# Altium schematic sheet ExtInterface_26P.SchDoc
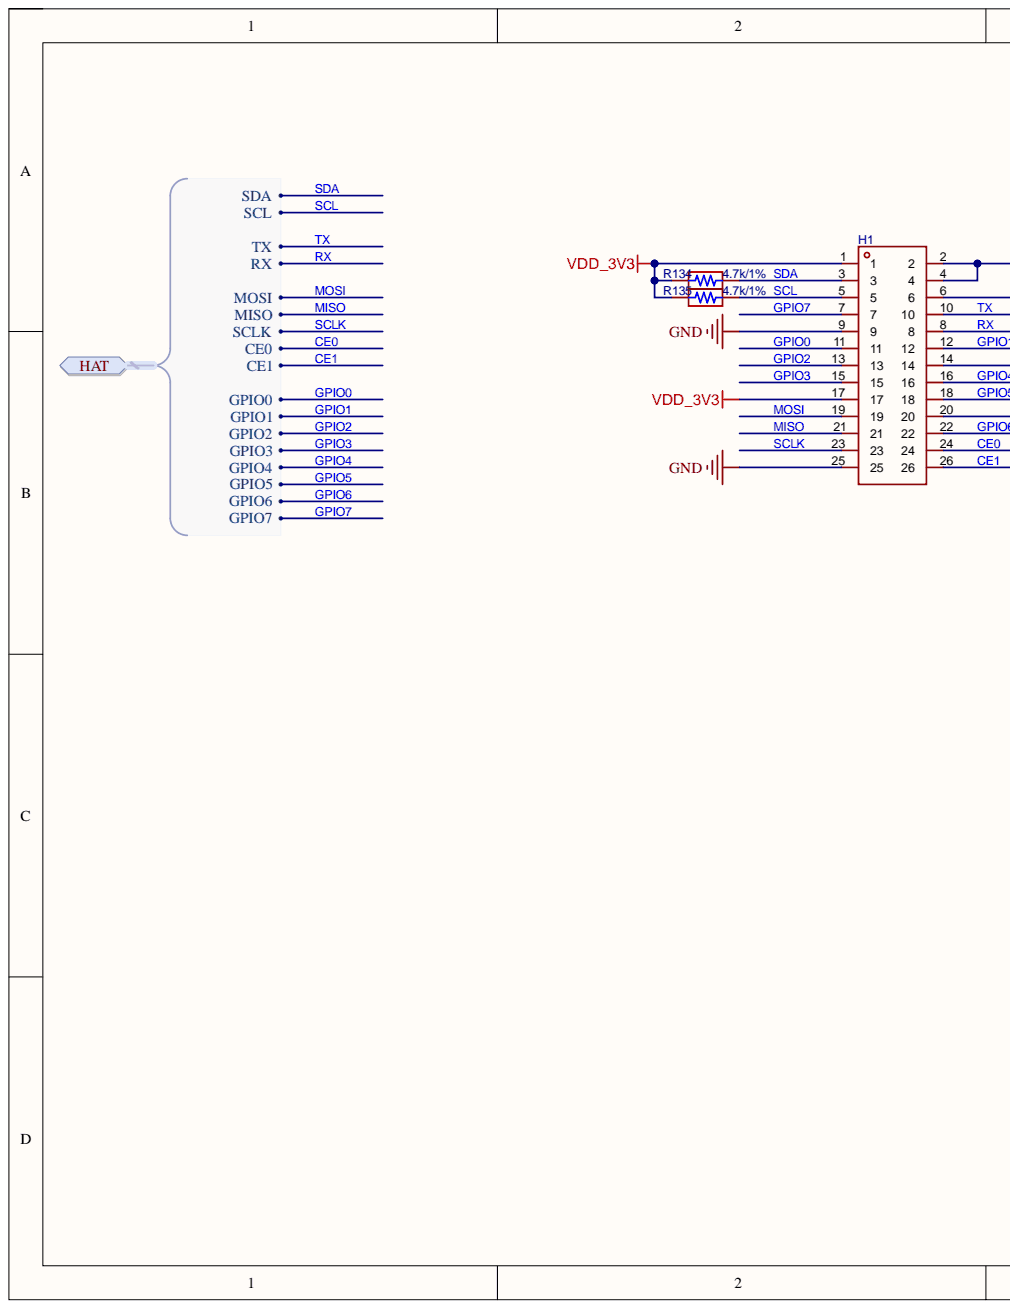
[diagram p0: ExtInterface_26P.SchDoc, left]
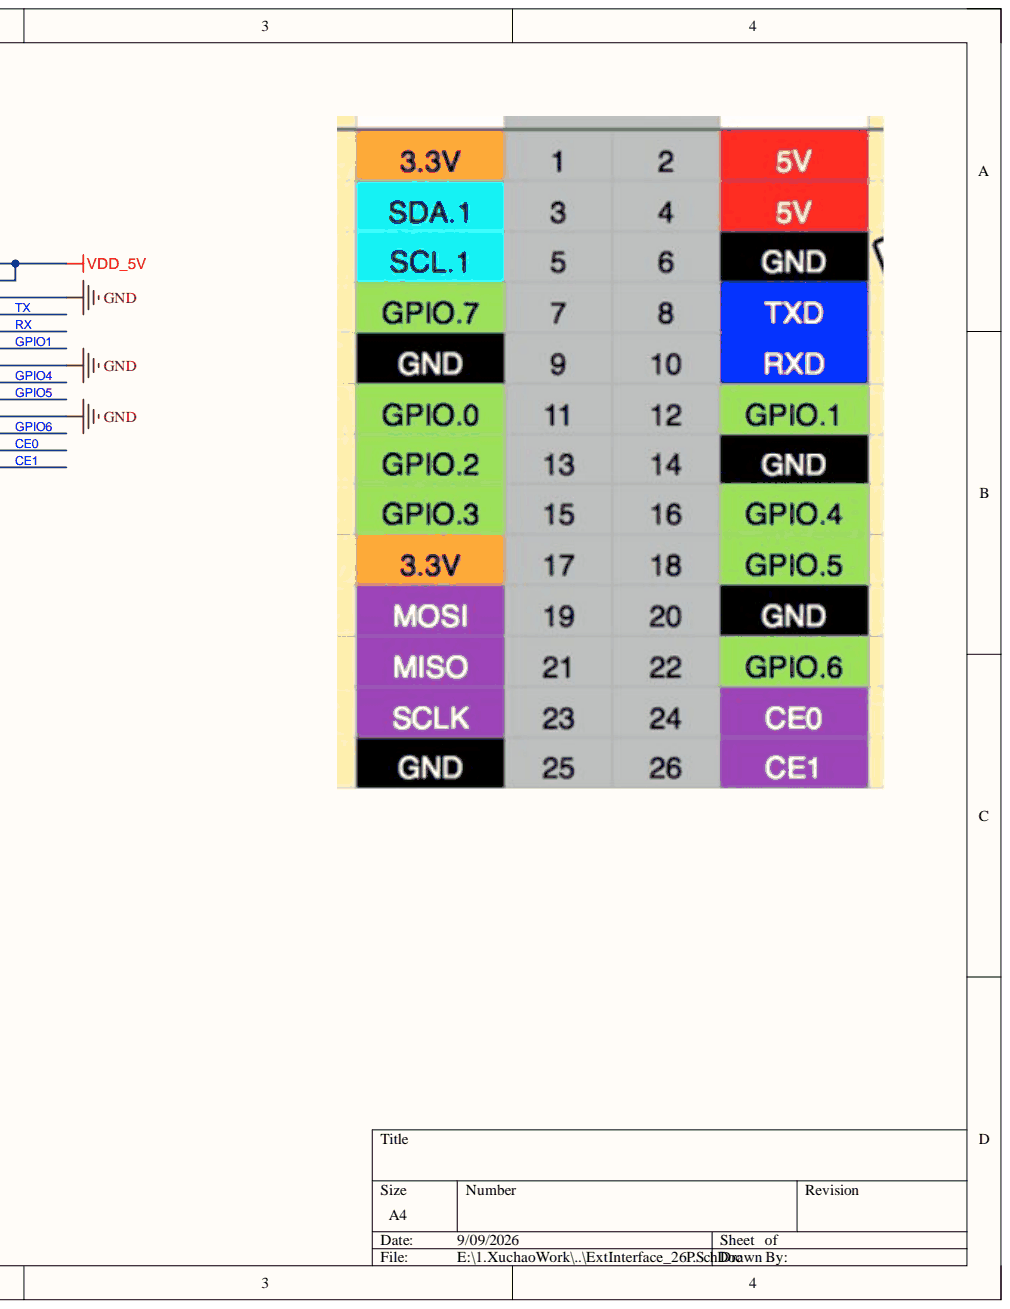
[diagram p1: ExtInterface_26P.SchDoc, right]

## Parts
H1  hc-pz254-11.5l-2x13pz  [HDR-TH_26P-P2.54-V-M-R2-C13-S2.54]  (value not drawn)
R134  4.7k/1%  [R0402]
R135  4.7k/1%  [R0402]

## Ports
HAT, HAT.CE0, HAT.CE1, HAT.GPIO0, HAT.GPIO1, HAT.GPIO2, HAT.GPIO3, HAT.GPIO4, HAT.GPIO5, HAT.GPIO6, HAT.GPIO7, HAT.MISO, HAT.MOSI, HAT.RX, HAT.SCL, HAT.SCLK, HAT.SDA, HAT.TX

## Nets
GND: H1.6 H1.9 H1.14 H1.20 H1.25
VDD_3V3: H1.1 H1.17 R134.2 R135.2
VDD_5V: H1.2 H1.4
CE0: H1.24 ; port HAT
CE1: H1.26 ; port HAT
GPIO0: H1.11 ; port HAT
GPIO1: H1.12 ; port HAT
GPIO2: H1.13 ; port HAT
GPIO3: H1.15 ; port HAT
GPIO4: H1.16 ; port HAT
GPIO5: H1.18 ; port HAT
GPIO6: H1.22 ; port HAT
GPIO7: H1.7 ; port HAT
MISO: H1.21 ; port HAT
MOSI: H1.19 ; port HAT
RX: H1.8 ; port HAT
SCL: H1.5 R135.1 ; port HAT
SCLK: H1.23 ; port HAT
SDA: H1.3 R134.1 ; port HAT
TX: H1.10 ; port HAT

## Library data of the parts
H1 = HC-PZ2.54V_2X13P: 插针结构:2x13P;间距:2.54mm;行距:2.54mm;圆针/方针:方针;安装方式:直插;排数:双排;总PIN位数:26P;额定电流:3A;额定电压:-;配合针长度:6mm;塑高:2.5mm;端接针长度:3mm;触头材质:铜合金;触头镀层:金;工作温度:-40℃~+105℃;颜色:黑色;; Manufacturer: HC(虹成电子); AddInBom: yes; Circluar Pins/Square Pins: 方针; Current Rating: 3A; ModelId: 5550078eeab944d391459ddd01fa55c0; ModelName: HDR-TH_26P-P2.54-M-LI-2X13P; ModelTransform: 1299.9974,196.85,0,0,0,0,0,0,-118.11; Pin Structure: 2x13P; Pitch: 2.54mm; Color: 黑色; Component Kind: Standard; Contact Material: 铜合金; Contact Plating: 金; ConvertToPcb: yes; Datasheet: https://item.szlcsc.com/datasheet/HC-PZ254-11.5L-2x13PZ/43143803.html; FootprintName: HDR-TH_26P-P2.54-V-M-R2-C13-S2.54; Insulation Height: 2.5mm; JLCPCB Part Class: Extended Part; LCSC Part Name: 2x13P 间距:2.54mm 方针 直插; Length of End Connection Pin: 3mm; Length of Mating Pin: 6mm; ManufacturerPart: HC-PZ254-11.5L-2x13PZ; Mounting Type: 直插; Number of Pins: 26P; Number of Rows: 双排; Operating Temperature: -40℃~+105℃; Row Spacing: 2.54mm; Supplier: LCSC; Supplier Footprint: 插件,P=2.54mm; SupplierPart: C41375954; Symbol: HC-PZ254-11.5L-2X13PZ; Voltage Rating (Max): -; Library Name: CYKJ_LibPkg.IntLib
R134 R135 = Resisteors: RES_SMD; Component Kind: Standard; Library Name: CYKJ_library.SchLib
